# Altium schematic sheet DEVICE3.SchDoc(DEVICE3)
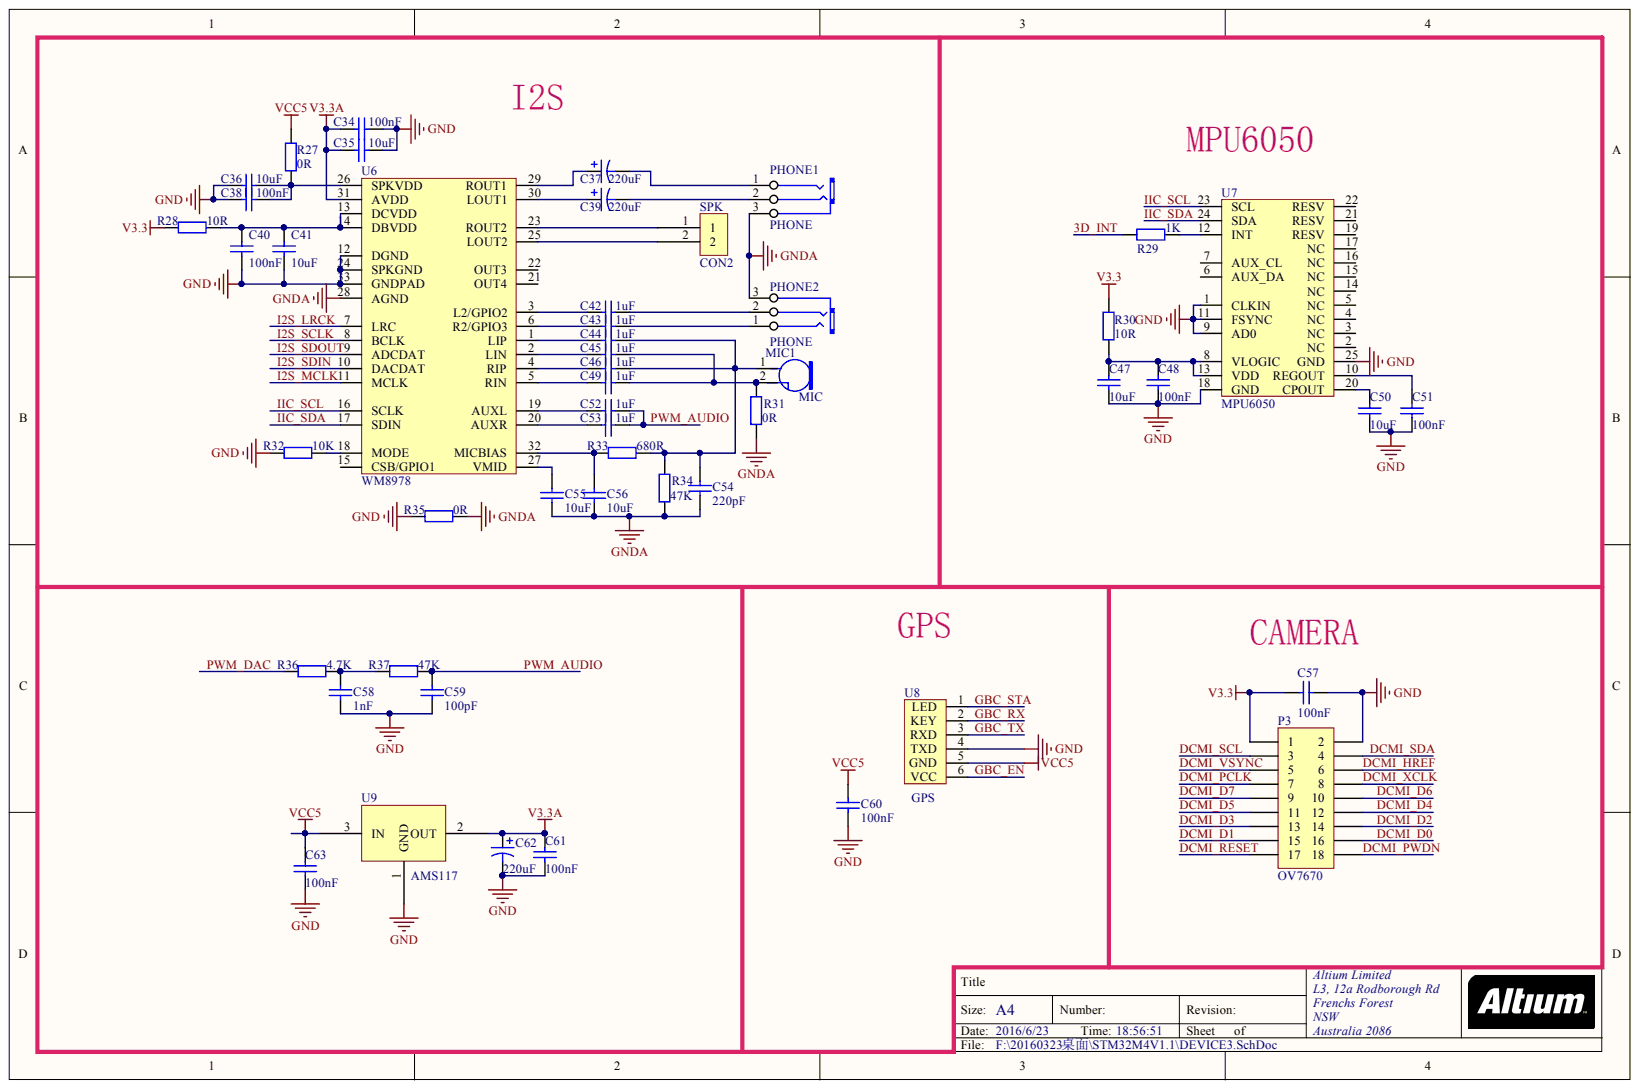
[diagram p0: DEVICE3.SchDoc]

## Parts
C34  value 100nF  [0603]  (hidden comment: Cap)
C35  value 10uF  [0805]  (hidden comment: Cap)
C36  value 10uF  [0805]  (hidden comment: Cap)
C37  value 220uF  [CAP-6.5*6.5-SOP]  (hidden comment: Cap Pol1)
C38  value 100nF  [0603]  (hidden comment: Cap)
C39  value 220uF  [CAP-6.5*6.5-SOP]  (hidden comment: Cap Pol1)
C40  value 100nF  [0603]  (hidden comment: Cap)
C41  value 10uF  [0805]  (hidden comment: Cap)
C42  value 1uF  [0603]  (hidden comment: Cap)
C43  value 1uF  [0603]  (hidden comment: Cap)
C44  value 1uF  [0603]  (hidden comment: Cap)
C45  value 1uF  [0603]  (hidden comment: Cap)
C46  value 1uF  [0603]  (hidden comment: Cap)
C47  value 10uF  [0805]  (hidden comment: Cap)
C48  value 100nF  [0603]  (hidden comment: Cap)
C49  value 1uF  [0603]  (hidden comment: Cap)
C50  value 10uF  [0805]  (hidden comment: Cap)
C51  value 100nF  [0603]  (hidden comment: Cap)
C52  value 1uF  [0603]  (hidden comment: Cap)
C53  value 1uF  [0603]  (hidden comment: Cap)
C54  value 220pF  [0603]  (hidden comment: Cap)
C55  value 10uF  [0805]  (hidden comment: Cap)
C56  value 10uF  [0805]  (hidden comment: Cap)
C57  value 100nF  [0603]  (hidden comment: Cap)
C58  value 1nF  [0603]  (hidden comment: Cap)
C59  value 100pF  [0603]  (hidden comment: Cap)
C60  value 100nF  [0603]  (hidden comment: Cap)
C61  value 100nF  [0603]  (hidden comment: Cap)
C62  value 220uF  [CAP-6.5*6.5-SOP]  (hidden comment: Cap Pol1)
C63  value 100nF  [0603]  (hidden comment: Cap)
MIC1  MIC  [MIC]
P3  OV7670  [WPZ_2x9]
PHONE1  PHONE  [PHONE_ST]
PHONE2  PHONE  [PHONE_ST]
R27  value 0R  [0603]  (hidden comment: Res2)
R28  value 10R  [0603]  (hidden comment: Res2)
R29  value 1K  [0603]  (hidden comment: Res2)
R30  value 10R  [0603]  (hidden comment: Res2)
R31  value 0R  [0603]  (hidden comment: Res2)
R32  value 10K  [0603]  (hidden comment: Res2)
R33  value 680R  [0603]  (hidden comment: Res2)
R34  value 47K  [0603]  (hidden comment: Res2)
R35  value 0R  [0603]  (hidden comment: Res2)
R36  value 4.7K  [0603]  (hidden comment: Res2)
R37  value 47K  [0603]  (hidden comment: Res2)
SPK  CON2  [CON2]
U6  WM8978  [LCC32]
U7  MPU6050  [LCC24]
U8  GPS  [HDR1X6]
U9  AMS117  [SOT-223]

## Nets
GND: C34.2 C35.2 C36.1 C38.1 C40.1 C41.1 C47.2 C48.2 C50.2 C51.2 C57.2 C58.2 C59.2 C60.1 C61.2 C62.2 C63.2 P3.2 R32.1 R35.2 U6.12(DGND) U6.24(SPKGND) U6.33(GNDPAD) U7.1(CLKIN) U7.9(AD0) U7.11(FSYNC) U7.18(GND) U7.25(GND) U8.4(TXD) U9.1
GNDA: C54.2 C55.2 C56.2 PHONE1.3 PHONE2.3 R31.1 R34.1 R35.1 U6.28(AGND)
V3.3: C57.1 P3.1 R28.1 R30.1
V3.3A: C34.1 C35.1 C61.1 C62.1 U6.31(AVDD) U9.2
VCC5: C60.2 C63.1 R27.1 U8.5(GND) U9.3
3D_INT: R29.2
DCMI_D0: P3.16
DCMI_D1: P3.15
DCMI_D2: P3.14
DCMI_D3: P3.13
DCMI_D4: P3.12
DCMI_D5: P3.11
DCMI_D6: P3.10
DCMI_D7: P3.9
DCMI_HREF: P3.6
DCMI_PCLK: P3.7
DCMI_PWDN: P3.18
DCMI_RESET: P3.17
DCMI_SCL: P3.3
DCMI_SDA: P3.4
DCMI_VSYNC: P3.5
DCMI_XCLK: P3.8
GBC_EN: U8.6(VCC)
GBC_RX: U8.2(KEY)
GBC_STA: U8.1(LED)
GBC_TX: U8.3(RXD)
I2S_LRCK: U6.7(LRC)
I2S_MCLK: U6.11(MCLK)
I2S_SCLK: U6.8(BCLK)
I2S_SDIN: U6.10(DACDAT)
I2S_SDOUT: U6.9(ADCDAT)
IIC_SCL: U6.16(SCLK) U7.23(SCL)
IIC_SDA: U6.17(SDIN) U7.24(SDA)
PWM_AUDIO: C52.1 C53.1 C59.1 R37.1
PWM_DAC: R36.2
NetC36_2: C36.2 C38.2 R27.2 U6.26(SPKVDD)
NetC37_1: C37.1 U6.29(ROUT1)
NetC37_2: C37.2 PHONE1.1
NetC39_1: C39.1 U6.30(LOUT1)
NetC39_2: C39.2 PHONE1.2
NetC40_2: C40.2 C41.2 R28.2 U6.13(DCVDD) U6.14(DBVDD)
NetC42_1: C42.1 PHONE2.2
NetC42_2: C42.2 U6.3(L2/GPIO2)
NetC43_1: C43.1 PHONE2.1
NetC43_2: C43.2 U6.6(R2/GPIO3)
NetC44_1: C44.1 C46.1 C54.1 MIC1.1 R33.1 R34.2
NetC44_2: C44.2 U6.1(LIP)
NetC45_1: C45.1 C49.1 MIC1.2 R31.2
NetC45_2: C45.2 U6.2(LIN)
NetC46_2: C46.2 U6.4(RIP)
NetC47_1: C47.1 C48.1 R30.2 U7.8(VLOGIC) U7.13(VDD)
NetC49_2: C49.2 U6.5(RIN)
NetC50_1: C50.1 U7.20(CPOUT)
NetC51_1: C51.1 U7.10(REGOUT)
NetC52_2: C52.2 U6.19(AUXL)
NetC53_2: C53.2 U6.20(AUXR)
NetC55_1: C55.1 U6.27(VMID)
NetC56_1: C56.1 R33.2 U6.32(MICBIAS)
NetC58_1: C58.1 R36.1 R37.2
NetR29_1: R29.1 U7.12(INT)
NetR32_2: R32.2 U6.18(MODE)
NetSPK_1: SPK.1 U6.23(ROUT2)
NetSPK_2: SPK.2 U6.25(LOUT2)

Not connected: U6 15(CSB/GPIO1) 21(OUT4) 22(OUT3); U7 2(NC) 3(NC) 4(NC) 5(NC) 6(AUX_DA) 7(AUX_CL) 14(NC) 15(NC) 16(NC) 17(NC) 19(RESV) 21(RESV) 22(RESV)

## Library data of the parts
C34-C36 C38 C40-C61 C63 = Cap: Capacitor; Component Kind: Standard; LatestRevisionDate: 17-Jul-2002; LatestRevisionNote: Re-released for DXP Platform.; Library Name: Miscellaneous Devices.IntLib; PackageDescription: Radial Cap, Thru-Hole; 2 Leads; 0.3 in Pin Spacing; PackageReference: RAD-0.3; Published: 8-Jun-2000; Publisher: Altium Limited
  C34 C36 C38 C40-C61 C63: Signal Integrity: Cap; Simulation: CAP
C37 C39 C62 = Cap Pol1: Polarized Capacitor (Radial); Component Kind: Standard; LatestRevisionDate: 17-Jul-2002; LatestRevisionNote: Re-released for DXP Platform.; Library Name: Miscellaneous Devices.IntLib; PackageDescription: Polarized Capacitor (Radial); 2 Leads; PackageReference: RB7.6-15; Published: 8-Jun-2000; Publisher: Altium Limited
P3 = Header 9X2: Header, 9-Pin, Dual row; Component Kind: Standard; LatestRevisionDate: 17-Jul-2002; LatestRevisionNote: Re-released for DXP Platform.; Library Name: Miscellaneous Connectors.IntLib; Publisher: Altium Limited
PHONE1 PHONE2 = PHONE_M: Component Kind: Standard; Library Name: MyLibrary.IntLib
R27-R37 = Res2: Resistor; Component Kind: Standard; LatestRevisionDate: 17-Jul-2002; LatestRevisionNote: Re-released for DXP Platform.; Library Name: Miscellaneous Devices.IntLib; PackageDescription: Axial Device, Thru-Hole; 2 Leads; 0.4 in Pin Spacing; PackageReference: AXIAL-0.4; Published: 8-Jun-2000; Signal Integrity: Res; Simulation: RESISTOR; Publisher: Altium Limited
U6 = WM8978_1: 24bit ADC&DAC; Component Kind: Standard; Library Name: MyLibrary.IntLib
U7 = MPU6050: 9轴运动处理传感器; Component Kind: Standard; Library Name: MyLibrary.SchLib
U8 = ATK-HC05: Component Kind: Standard; Description: ATK-HC05; Library Name: MyLibrary.IntLib
U9 = AMS117: 稳压芯片; Component Kind: Standard; Library Name: MyLibrary.IntLib
MIC1 = MIC: Component Kind: Standard; Library Name: MyLibrary.IntLib
SPK = CON2: Component Kind: Standard; Library Name: M4.SCHLIB
